# Altium schematic sheet P08_eMMC.SchDoc(P08_eMMC)
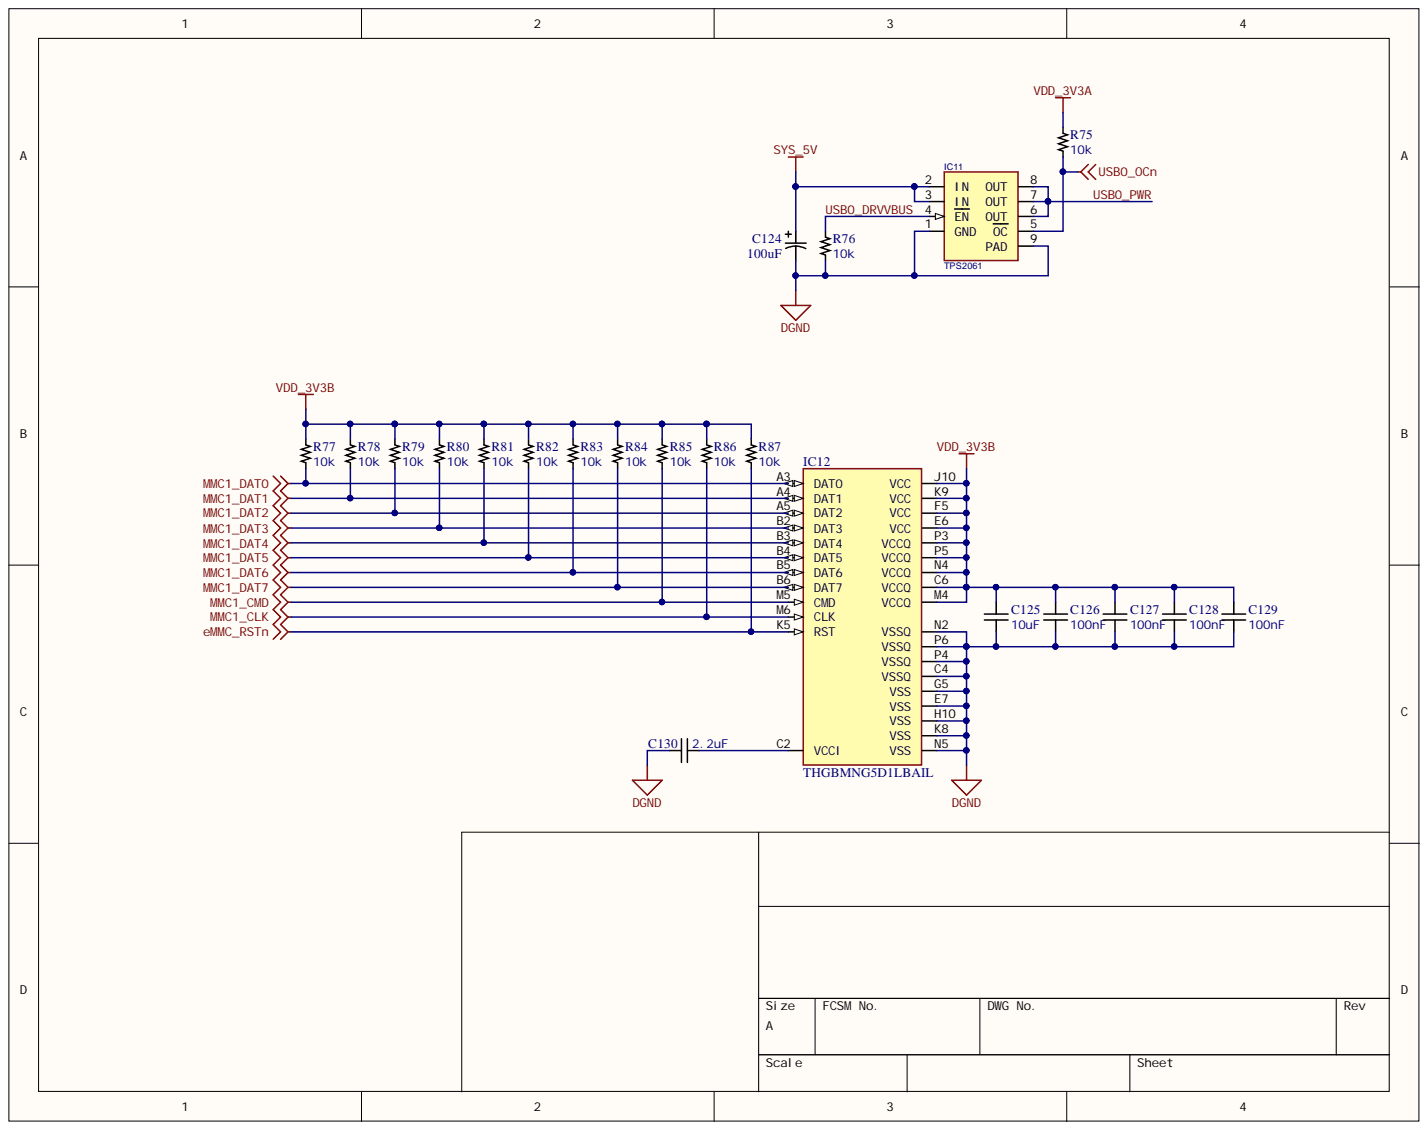
[diagram p0: P08_eMMC.SchDoc]

## Parts
C124  Capacitance: 100uF  [CAP6.6x6.6]  (hidden comment: EEE-0JA101SP)
C125  Capacitance: 10uF  [CAP0805]  (hidden comment: C2012X6S1A106K085AC)
C126  Capacitance: 100nF  [CAP0402]  (hidden comment: C0402C104K4RACTU)
C127  Capacitance: 100nF  [CAP0402]  (hidden comment: C0402C104K4RACTU)
C128  Capacitance: 100nF  [CAP0402]  (hidden comment: C0402C104K4RACTU)
C129  Capacitance: 100nF  [CAP0402]  (hidden comment: C0402C104K4RACTU)
C130  Capacitance: 2.2uF  [CAP0402]  (hidden comment: C1005X5R0J225K050BC)
IC11  TPS2061  [HVSSOP-8]
IC12  THGBMNG5D1LBAIL  [WFBGA-153]
R75  Resistance: 10k  [RES0402]  (hidden comment: RC0402FR-0710KL)
R76  Resistance: 10k  [RES0402]  (hidden comment: RC0402FR-0710KL)
R77  Resistance: 10k  [RES0402]  (hidden comment: RC0402FR-0710KL)
R78  Resistance: 10k  [RES0402]  (hidden comment: RC0402FR-0710KL)
R79  Resistance: 10k  [RES0402]  (hidden comment: RC0402FR-0710KL)
R80  Resistance: 10k  [RES0402]  (hidden comment: RC0402FR-0710KL)
R81  Resistance: 10k  [RES0402]  (hidden comment: RC0402FR-0710KL)
R82  Resistance: 10k  [RES0402]  (hidden comment: RC0402FR-0710KL)
R83  Resistance: 10k  [RES0402]  (hidden comment: RC0402FR-0710KL)
R84  Resistance: 10k  [RES0402]  (hidden comment: RC0402FR-0710KL)
R85  Resistance: 10k  [RES0402]  (hidden comment: RC0402FR-0710KL)
R86  Resistance: 10k  [RES0402]  (hidden comment: RC0402FR-0710KL)
R87  Resistance: 10k  [RES0402]  (hidden comment: RC0402FR-0710KL)

## Nets
DGND: C124.2 C125.1 C126.2 C127.2 C128.2 C129.2 C130.2 IC11.1(GND) IC11.9(PAD) IC12.C4(VSSQ) IC12.E7(VSS) IC12.G5(VSS) IC12.H10(VSS) IC12.K8(VSS) IC12.N2(VSSQ) IC12.N5(VSS) IC12.P4(VSSQ) IC12.P6(VSSQ) R76.2
eMMC_RSTn: IC12.K5(RST) R87.1
MMC1_CLK: IC12.M6(CLK) R86.1
MMC1_CMD: IC12.M5(CMD) R85.1
MMC1_DAT0: IC12.A3(DAT0) R77.1
MMC1_DAT1: IC12.A4(DAT1) R78.1
MMC1_DAT2: IC12.A5(DAT2) R79.1
MMC1_DAT3: IC12.B2(DAT3) R80.1
MMC1_DAT4: IC12.B3(DAT4) R81.1
MMC1_DAT5: IC12.B4(DAT5) R82.1
MMC1_DAT6: IC12.B5(DAT6) R83.1
MMC1_DAT7: IC12.B6(DAT7) R84.1
SYS_5V: C124.1 IC11.2(IN) IC11.3(IN)
USB0_OCn: IC11.5(OC) R75.2
VDD_3V3A: R75.1
VDD_3V3B: C125.2 C126.1 C127.1 C128.1 C129.1 IC12.C6(VCCQ) IC12.E6(VCC) IC12.F5(VCC) IC12.J10(VCC) IC12.K9(VCC) IC12.M4(VCCQ) IC12.N4(VCCQ) IC12.P3(VCCQ) IC12.P5(VCCQ) R77.2 R78.2 R79.2 R80.2 R81.2 R82.2 R83.2 R84.2 R85.2 R86.2 R87.2
USB0_DRVVBUS: IC11.4(EN) R76.1
USB0_PWR: IC11.6(OUT) IC11.7(OUT) IC11.8(OUT)
NetC130_1: C130.1 IC12.C2(VCCI)

## Library data of the parts
C124 = CAP_POL_100uF: POL CAP 100uF SMD; Manufacturer: Panasonic; Voltage Rating (DC): 6.3V; Tolerance: 20%; Ripple Current (AC): 71mA; Life (Hours): 2000Hour; Leakage Current: 3uA; Lead Length: 2.6mm; Dielectric Material: Aluminium; Width: 6.6mm; Voltage Rating: 6.3V; Voltage: 6.3V; Termination: SMD/SMT; Supplier Part Number 1: PCE3852CT-ND; Supplier 1: Digi-Key; Stock 1: 67789; RoHS: Yes; Ripple Current: 71mA; REACH SVHC: No SVHC; Radiation Hardening: No; Pricing 1: 1=0.35000, 10=0.24000, 100=0.14420, 500=0.10816 (USD); Packaging: Tape and Reel; Package Quantity: 1; Number of Pins: 2; Mount: Surface Mount,PCB; Min Operating Temperature: -40°C; Max Operating Temperature: 85°C; Manufacturer Part Number: EEE-0JA101SP; Length: 5.5mm; Lead Pitch: 2.2mm; Lead Free: Lead Free; Height - Seated (Max): 0.213inch; Height: 5.4mm; Features: General Purpose; Diameter: 6.3mm; Contact Plating: Tin; ComponentLink14URL: https://datasheet.ciiva.com/17353/aba0000ce2-17353295.pdf?src-supplier=Avnet+Europe; ComponentLink14Description: https://datasheet.ciiva.com/17353/aba0000ce2-17353295.pdf?src-supplier=Avnet+Europe; ComponentLink13URL: https://datasheet.ciiva.com/15165/getdatasheetpartid-73778-15165850.pdf?src-supplier=Verical; ComponentLink13Description: https://datasheet.ciiva.com/15165/getdatasheetpartid-73778-15165850.pdf?src-supplier=Verical; ComponentLink12URL: https://datasheet.ciiva.com/16539/aba0000ce2-16539929.pdf?src-supplier=Avnet+Asia; ComponentLink12Description: https://datasheet.ciiva.com/16539/aba0000ce2-16539929.pdf?src-supplier=Avnet+Asia; ComponentLink11URL: https://datasheet.ciiva.com/6134/aba0000ce2-6134361.pdf?src-supplier=Farnell; ComponentLink11Description: https://datasheet.ciiva.com/6134/aba0000ce2-6134361.pdf?src-supplier=Farnell; ComponentLink10URL: https://datasheet.ciiva.com/46376/aba0000ce2-46376375.pdf?src-supplier=Element14; ComponentLink10Description: https://datasheet.ciiva.com/46376/aba0000ce2-46376375.pdf?src-supplier=Element14; ComponentLink9URL: https://datasheet.ciiva.com/24070/aba0000ce2-40801-24070230.pdf?src-supplier=Mouser; ComponentLink9Description: https://datasheet.ciiva.com/24070/aba0000ce2-40801-24070230.pdf?src-supplier=Mouser; ComponentLink8URL: https://datasheet.ciiva.com/43485/aba0000ce2-43485605.pdf?src-supplier=Farnell; ComponentLink8Description: https://datasheet.ciiva.com/43485/aba0000ce2-43485605.pdf?src-supplier=Farnell; ComponentLink7URL: https://datasheet.ciiva.com/2983/aba0000ce2-2983902.pdf?src-supplier=Avnet; ComponentLink7Description: https://datasheet.ciiva.com/2983/aba0000ce2-2983902.pdf?src-supplier=Avnet; ComponentLink6URL: https://datasheet.ciiva.com/14595/70255710-14595757.pdf?src-supplier=Allied+Electronics; ComponentLink6Description: https://datasheet.ciiva.com/14595/70255710-14595757.pdf?src-supplier=Allied+Electronics; ComponentLink5URL: https://datasheet.ciiva.com/46387/aba0000ce2-46387964.pdf?src-supplier=Element14; ComponentLink5Description: https://datasheet.ciiva.com/46387/aba0000ce2-46387964.pdf?src-supplier=Element14; ComponentLink4URL: https://datasheet.ciiva.com/16397/aba0000ce2-16397066.pdf?src-supplier=Future+Electronics; ComponentLink4Description: https://datasheet.ciiva.com/16397/aba0000ce2-16397066.pdf?src-supplier=Future+Electronics; ComponentLink3URL: https://datasheet.ciiva.com/30226/11aba0000ce2-30226184.pdf?src-supplier=Onlinecomponents.com; ComponentLink3Description: https://datasheet.ciiva.com/30226/11aba0000ce2-30226184.pdf?src-supplier=Onlinecomponents.com; ComponentLink2URL: https://datasheet.ciiva.com/14610/70255710-14610022.pdf?src-supplier=Allied+Electronics; ComponentLink2Description: https://datasheet.ciiva.com/14610/70255710-14610022.pdf?src-supplier=Allied+Electronics; ComponentLink1URL: https://datasheet.ciiva.com/11779/0900766b8078636e-11779744.pdf?src-supplier=RSComponents; ComponentLink1Description: https://datasheet.ciiva.com/11779/0900766b8078636e-11779744.pdf?src-supplier=RSComponents; Component Kind: Standard; Case/Package: Radial; Library Name: Orient.SchLib
C125 = CAP_10uF: MLCC 10uF 0805 SMD; Manufacturer: TDK; Voltage Rating (DC): 10V; Tolerance: 10%; Dielectric Material: Ceramic; Dielectric: X6S; Width: 0.049inch; Weight: 0.000194oz; Voltage Rating: 10V; Thickness: 0.037inch; Termination: SMD/SMT; Supplier Part Number 1: 445-7613-1-ND; Supplier 1: Digi-Key; Stock 1: 46852; RoHS: Yes; REACH SVHC: No SVHC; Pricing 1: 1=0.30000, 10=0.20500, 100=0.11610, 500=0.08198, 1000=0.07173 (USD); Packaging: Tape and Reel; Package Quantity: 4000; Mount: Surface Mount; Min Operating Temperature: -55°C; Max Operating Temperature: 105°C; Manufacturer Part Number: C2012X6S1A106K085AC; Length: 2mm; Lead Free: Lead Free; Height: 1.25mm; Depth: 1.25mm; Composition: Ceramic; ComponentLink3URL: https://datasheet.ciiva.com/6290/tdkmlcccaprange-6290501.pdf?src-supplier=Digi-Key; ComponentLink3Description: Datasheet; Component Kind: Standard; Case Code (Metric): 2012; Case Code (Imperial): 0805; Case/Package: 0805; Library Name: Orient.SchLib
C126-C129 = CAP_100nF: MLCC 100nF 0402 SMD; Manufacturer: KEMET; Voltage Rating (DC): 16V; Tolerance: 10%; Dissipation Factor: 3.5%; Dielectric: X7R; Width: 0.5mm; Voltage Rating: 16V; Termination: SMD/SMT; Supplier Part Number 1: 399-3521-1-ND; Supplier 1: Digi-Key; Stock 1: 6512243; RoHS: Yes; Radiation Hardening: No; Pricing 1: 1=0.10000, 10=0.04200, 100=0.01860, 500=0.01328, 1000=0.01044, 2500=0.00949, 5000=0.00873 (USD); Packaging: Tape and Reel; Package Quantity: 10000; Mount: Surface Mount; Min Operating Temperature: -55°C; Max Operating Temperature: 125°C; Manufacturer Part Number: C0402C104K4RACTU; Length: 1mm; Height: 0.5mm; Depth: 0.5mm; Contact Plating: Tin,Matte; ComponentLink2URL: https://datasheet.ciiva.com/18192/getdatasheetpartid-385384-18192412.pdf?src-supplier=Verical; ComponentLink2Description: Datasheet; Component Kind: Standard; Case Code (Metric): 1005; Case Code (Imperial): 0402; Case/Package: 0402; Library Name: Orient.SchLib
C130 = CAP_2.2uF: MLCC 2.2uF 0402 SMD; Manufacturer: TDK; Voltage Rating (DC): 6.3V; Tolerance: 10%; Dielectric Material: Ceramic; Dielectric: X5R; Width: 0.02inch; Weight: 2.3E-05oz; Voltage Rating: 6.3V; Voltage: 6.3V; Thickness: 0.022inch; Termination: SMD/SMT; Supplier 1: Digi-Key; Stock 1: 256055; RoHS: Yes; REACH SVHC: No SVHC; Radiation Hardening: No; Pricing 1: 1=0.20000, 10=0.13800, 100=0.07010, 500=0.04794, 1000=0.04240, 2500=0.04056, 5000=0.03687 (USD); Packaging: Tape and Reel; Package Quantity: 1; Number of Pins: 2; Mount: Surface Mount; Min Operating Temperature: -55°C; Max Operating Temperature: 85°C; Manufacturer Part Number: C1005X5R0J225K050BC; Length: 1mm; Lead Free: Lead Free; Height: 0.5mm; Depth: 0.5mm; Composition: Ceramic; ComponentLink7URL: https://product.tdk.com/info/en/catalog/datasheets/mlcc_commercial_general_en.pdf?ref_disty=digikey; ComponentLink7Description: Datasheet; Component Kind: Standard; Case Code (Metric): 1005; Case Code (Imperial): 0402; Case/Package: 0402; Library Name: Orient.SchLib
IC11 = TPS2061: IC 1.5A PWR DIST SWITCH 8-SOIC; Manufacturer Part Number: TPS2051BDGNR; Manufacturer: Texas Instruments; Voltage - Input: 2.7 V ~ 5.5 V; Rds (On): 135 mOhm; Number of Outputs: 1; Internal Switch(s): Yes; Current Limit: 1A; Type: High Side Switch; Supplier Part Number 1: 296-17313-6-ND; Supplier Device Package: 8-MSOP-PowerPad; Supplier 1: Digi-Key; Stock 1: 7065; RoHS: RoHS Compliant; Pricing 1: 1=1.82, 10=1.642, 25=1.4708, 100=1.3224, 250=1.1742, 500=1.026, 1000=0.8493 (USD); Packaging: Digi-Reel®; Package / Case: 8-TSSOP, 8-MSOP (0.118", 3.00mm Width) Exposed Pad; Operating Temperature: -40°C ~ 85°C; Mounting Type: Surface Mount; ComponentLink1URL: http://www.ti.com/lit/ds/symlink/tps2061.pdf; ComponentLink1Description: Datasheet; Component Kind: Standard; Category: Integrated Circuits (ICs); Library Name: Orient.SchLib
IC12 = IC_eMMC: Flash Card 4G-byte 3.3V MultiMedia Card 153-Pin WFBGA; Manufacturer Part Number: MTFC2GMVEA-0M WT; Manufacturer: Micron Technology; Lead Time: 2 weeks; Supplier Part Number 2: 757-THGBMNG5D1LBAIL; Supplier Part Number 1: 53710993S251949N2406; Supplier 2: Mouser; Supplier 1: Arrow; Stock 2: 1469; Stock 1: 1009; RoHS: CHINA ROHS: Compliant  EU ROHS: Compliant; Pricing 2: 1=5.85000, 10=5.34000, 25=5.24000, 50=5.20000, 100=4.67000, 250=4.65000, 500=4.36000, 1000=4.17000, 2500=3.91000 (USD); Pricing 1: 0=7.21 (USD); Package Quantity: 1; EU_ROHS_PROD_EXEMPTION_BY_SUPP: N/R; ComponentLink1URL: https://www.digikey.com/product-detail/en/kioxia-america-inc/THGBMNG5D1LBAIL/THGBMNG5D1LBAIL-ND/9841782; ComponentLink1Description: Digikey; Component Kind: Standard; Library Name: Orient.SchLib
R75-R87 = RES_10k: Resistor 10kOhm 0402 SMD; Manufacturer: Yageo; Working Voltage: 50V; Tolerance: 1%; Temperature Coefficient: 100ppm/°C; Power Rating: 62.5mW; Width: 0.5mm; Voltage Rating (DC): 50V; Voltage Rating: 50V; Termination: SMD/SMT; Supplier 1: Digi-Key; Stock 1: 72891652; RoHS: Yes; REACH SVHC: No SVHC; Pricing 1: 1=0.10000, 10=0.01500, 100=0.00600, 1000=0.00270, 2500=0.00235, 5000=0.00194 (USD); Packaging: Tape and Reel; Number of Terminations: 2; Number of Pins: 2; Min Operating Temperature: -55°C; Military Standard: Not; Max Operating Temperature: 155°C; Manufacturer Part Number: RC0402FR-0710KL; Length: 1mm; Lead Pitch: 0.2mm; Lead Free: Lead Free; Height: 0.32mm; Depth: 0.5mm; Composition: Thick Film; ComponentLink7URL: https://datasheet.ciiva.com/12667/pyu-rc0402-51-rohs-l-6-r-12667067.pdf?src-supplier=Mouser; ComponentLink7Description: https://datasheet.ciiva.com/12667/pyu-rc0402-51-rohs-l-6-r-12667067.pdf?src-supplier=Mouser; ComponentLink6URL: https://datasheet.ciiva.com/22747/1018594-22747512.pdf?src-supplier=Element14; ComponentLink6Description: https://datasheet.ciiva.com/22747/1018594-22747512.pdf?src-supplier=Element14; ComponentLink5URL: https://datasheet.ciiva.com/7849/1784430-7849815.pdf?src-supplier=Element14; ComponentLink5Description: https://datasheet.ciiva.com/7849/1784430-7849815.pdf?src-supplier=Element14; ComponentLink4URL: https://datasheet.ciiva.com/7849/1686128-7849814.pdf?src-supplier=Element14; ComponentLink4Description: https://datasheet.ciiva.com/7849/1686128-7849814.pdf?src-supplier=Element14; ComponentLink3URL: https://datasheet.ciiva.com/7849/1784430-7849813.pdf?src-supplier=Element14; ComponentLink3Description: https://datasheet.ciiva.com/7849/1784430-7849813.pdf?src-supplier=Element14; ComponentLink2URL: https://datasheet.ciiva.com/7983/1018594-7983486.pdf?src-supplier=Element14; ComponentLink2Description: https://datasheet.ciiva.com/7983/1018594-7983486.pdf?src-supplier=Element14; ComponentLink1URL: https://datasheet.ciiva.com/7660/38568-7660787.pdf?src-supplier=Element14; ComponentLink1Description: https://datasheet.ciiva.com/7660/38568-7660787.pdf?src-supplier=Element14; Component Kind: Standard; Case Code (Metric): 1005; Case Code (Imperial): 0402; Case/Package: 0402; Library Name: Orient.SchLib
